# Altium schematic sheet connectors.SchDoc
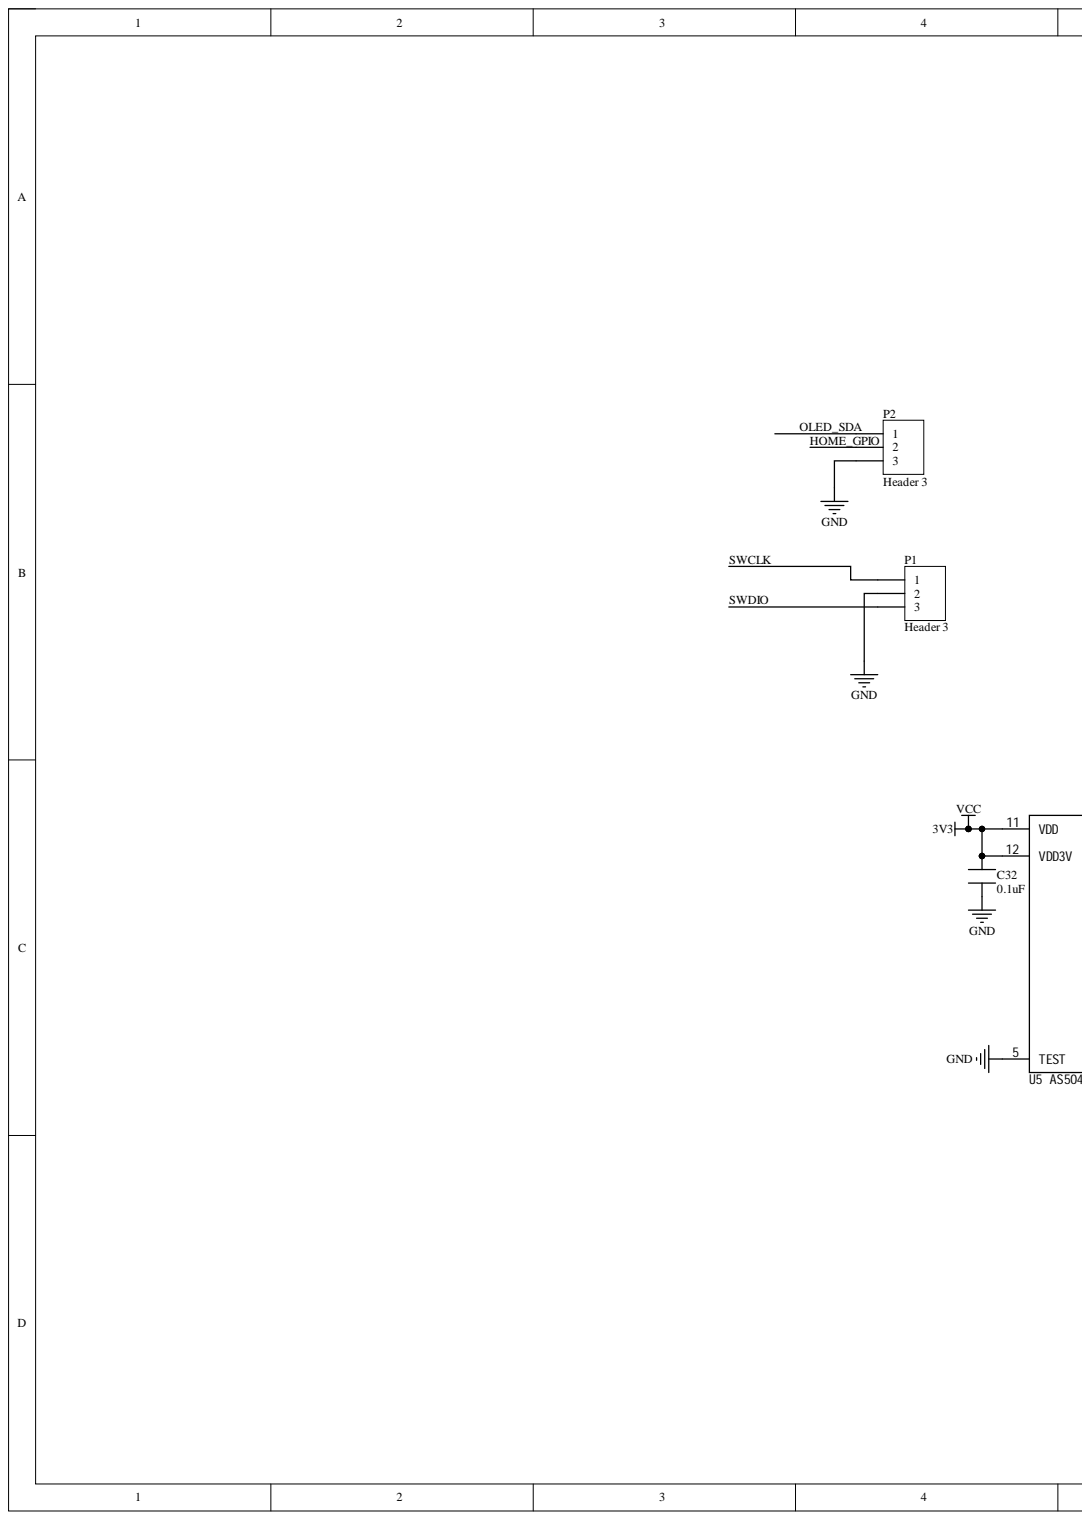
[diagram p0: connectors.SchDoc, left]
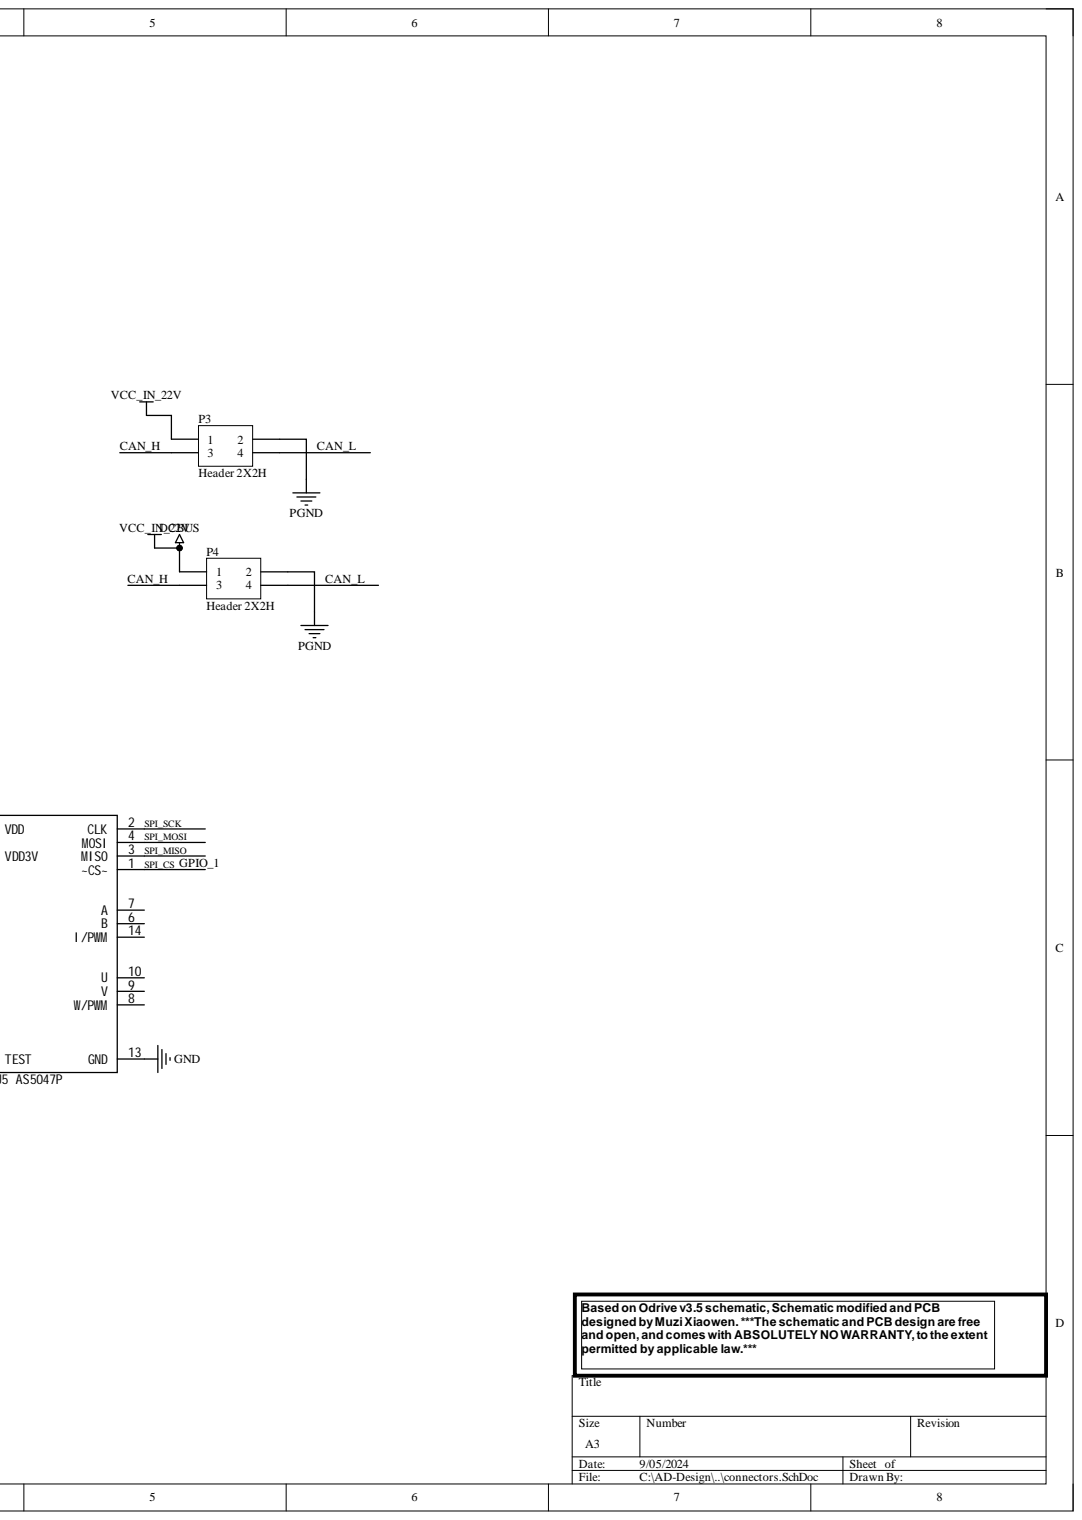
[diagram p1: connectors.SchDoc, right]

## Parts
C32  0.1uF  [CAP_0402]
P1  Header 3  [HDR1X3]
P2  Header 3  [CONN-TH_HC-ZH1.5-3A-S]
P3  Header 2X2H  [3D-CONN-TH_4P-P3.00_HC-MX3.0-2X2AW]
P4  Header 2X2H  [3D-CONN-TH_4P-P3.00_HC-MX3.0-2X2AW]
U5  AS5047P  [TSSOP14_2]

## Nets
3V3: C32.1 U5.11(VDD) U5.12(VDD3V)
DCBUS: P3.1 P4.1
GND: C32.2 P1.2 P2.3 U5.5(TEST) U5.13(GND)
PGND: P3.2 P4.2
CAN_H_1: P3.3 P4.3  [also labelled CAN_H]
CAN_L_1: P3.4 P4.4  [also labelled CAN_L]
GPIO_1_1: U5.1(~CS~)  [also labelled GPIO_1]
HOME_GPIO_1: P2.2  [also labelled HOME_GPIO]
OLED_SDA_1: P2.1  [also labelled OLED_SDA]
SPI_MISO_1: U5.3(MISO)  [also labelled SPI_MISO]
SPI_MOSI_1: U5.4(MOSI)  [also labelled SPI_MOSI]
SPI_SCK_1: U5.2(CLK)  [also labelled SPI_SCK]
SWCLK_1: P1.1  [also labelled SWCLK]
SWDIO_1: P1.3  [also labelled SWDIO]

Not connected: U5 6(B) 7(A) 8(W/PWM) 9(V) 10(U) 14(I/PWM)

## Library data of the parts
C32 = GRM188R71H104KA93D: CAP CER 0.1UF 50V X7R 0603; Manufacturer: Murata Electronics North America; Tolerance: ±10%; Applications: General Purpose; Capacitance: 0.1uF; Category: Capacitors; Component Kind: Standard; ComponentLink1Description: http://www.murata.com/~/media/webrenewal/support/library/catalog/products/capacitor/mlcc/c02e.ashx?la=en-us; ComponentLink1URL: http://www.murata.com/~/media/webrenewal/support/library/catalog/products/capacitor/mlcc/c02e.ashx?la=en-us; Features: -; Height - Seated (Max): -; Lead Spacing: -; Lead Style: -; Library Name: CustomComponents.SchLib; Manufacturer Part Number: GRM188R71H104KA93D; Mounting Type: Surface Mount, MLCC; Operating Temperature: -55°C ~ 125°C; Package / Case: 0603 (1608 Metric); Packaging: Tape & Reel (TR); Part Status: Active; Pricing 1: 4000=0.01822, 8000=0.01663, 12000=0.01584 (USD); Pricing 2: 1=0.12, 10=0.084, 100=0.0396, 500=0.02778, 1000=0.023 (USD); Ratings: -; RoHS: RoHS Compliant; Size / Dimension: 0.063" L x 0.032" W (1.60mm x 0.80mm); Stock: 19812000; Supplier 1: Digi-Key; Supplier 2: Digi-Key; Supplier Part Number 1: 490-1519-2-ND; Supplier Part Number 2: 490-1519-1-ND; Temperature Coefficient: X7R; Thickness (Max): 0.035" (0.90mm); Voltage - Rated: 50V
P1 P2 = Header 3: Header, 3-Pin; Component Kind: Standard; LatestRevisionDate: 17-Jul-2002; LatestRevisionNote: Re-released for DXP Platform.; Library Name: Miscellaneous Connectors.IntLib; Signal Integrity: Connector; Publisher: Altium Limited
P3 P4 = Header 2X2H: Header, 2-Pin, Dual row, Right Angle; Component Kind: Standard; LatestRevisionDate: 17-Jul-2002; LatestRevisionNote: Re-released for DXP Platform.; Library Name: Miscellaneous Connectors.IntLib; Signal Integrity: Connector; Publisher: Altium Limited
U5 = AS5047P: Add into BOM: yes; Origin Footprint: TSSOP-14; Component Kind: Standard; Convert to PCB: yes; Device: AS5047P; Name: AS5047P; Symbol: AS5047P
